# Altium schematic sheet PERIPHERAL.SchDoc
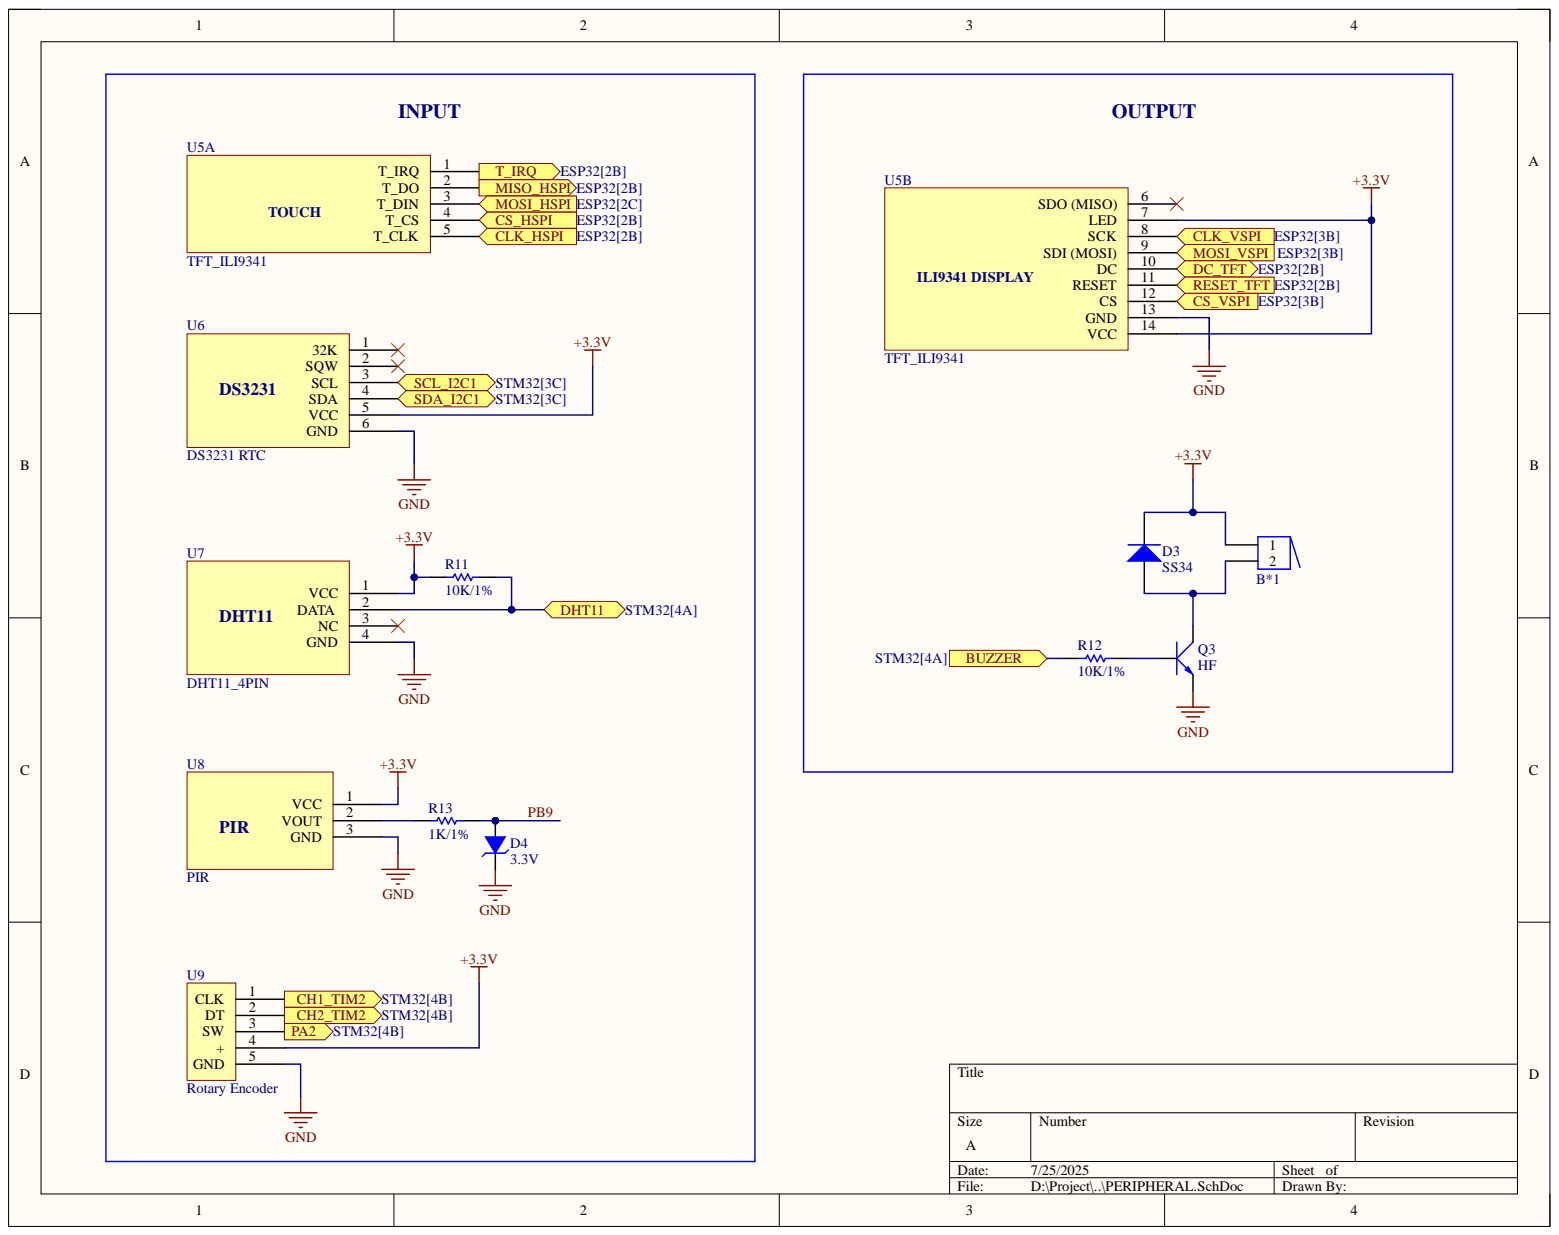
[diagram p0: PERIPHERAL.SchDoc]

## Parts
B*1  Buzzer  [BUZZER]  (value not drawn)
D3  SS34  [DIODE SMA]
D4  3.3V  [DIODE ZENNER SMD SOD80]
Q3  HF  [SOT-23]
R11  value 10K/1%  [RES-SM-0805]  (hidden comment: RESISTOR SMD)
R12  value 10K/1%  [RES-SM-0805]  (hidden comment: RESISTOR SMD)
R13  value 1K/1%  [RES-SM-0805]  (hidden comment: RESISTOR SMD)
U5  TFT_ILI9341  [header 14 pin]  (parts A,B)
U6  DS3231 RTC  [header 6 pin]
U7  DHT11_4PIN  [Header 4 Pin]
U8  PIR  [Header 3 Pin]  (value not drawn)
U9  Rotary Encoder  [Header 5 Pin]

## Ports
BUZZER, CH1_TIM2, CH2_TIM2, CLK_HSPI, CLK_VSPI, CS_HSPI, CS_VSPI, DC_TFT, DHT11, MISO_HSPI, MOSI_HSPI, MOSI_VSPI, PA2, RESET_TFT, SCL_I2C1, SDA_I2C1, T_IRQ

## Nets
+3.3V: B*1.1 D3.2 R11.2 U5.7(LED) U5.14(VCC) U6.5(VCC) U7.1(VCC) U8.1 U9.4(+)
GND: D4.2 Q3.2 U5.13(GND) U6.6(GND) U7.4(GND) U8.3 U9.5(GND)
BUZZER: R12.1 ; port BUZZER
CH1_TIM2: U9.1(CLK) ; port CH1_TIM2
CH2_TIM2: U9.2(DT) ; port CH2_TIM2
CLK_HSPI: U5.5(T_CLK) ; port CLK_HSPI
CLK_VSPI: U5.8(SCK) ; port CLK_VSPI
CS_HSPI: U5.4(T_CS) ; port CS_HSPI
CS_VSPI: U5.12(CS) ; port CS_VSPI
DC_TFT: U5.10(DC) ; port DC_TFT
DHT11: R11.1 U7.2(DATA) ; port DHT11
MISO_HSPI: U5.2(T_DO) ; port MISO_HSPI
MOSI_HSPI: U5.3(T_DIN) ; port MOSI_HSPI
MOSI_VSPI: U5.9(SDI_(MOSI)) ; port MOSI_VSPI
PA2: U9.3(SW) ; port PA2
PB9: D4.1 R13.2
RESET_TFT: U5.11(RESET) ; port RESET_TFT
SCL_I2C1: U6.3(SCL) ; port SCL_I2C1
SDA_I2C1: U6.4(SDA) ; port SDA_I2C1
T_IRQ: U5.1(T_IRQ) ; port T_IRQ
NetB*1_2: B*1.2 D3.1 Q3.3
NetQ3_1: Q3.1 R12.2
NetR13_1: R13.1 U8.2

Not connected: U5 6(SDO_(MISO)); U6 1(32K) 2(SQW); U7 3(NC)

## Library data of the parts
D3 = Diode SS34 ( 1N5822 ): Dán; Don_Vi: Con; Ma_Hang: MH002104; Ten_Linh_Kien: Diode SS34 3A 40V SMA ( 1N5822 SS34A); Chung_Loai: Dán; Component Kind: Standard; Library Name: Altium_Lib_by_KhueNguyenCreator.IntLib
D4 = Diode Zener 3.3V: Dán; Don_Vi: Con; Ma_Hang: MH002880; Ten_Linh_Kien: Diode Zener 1/2W 3.3V SMD1206 ZMM3.3; Chung_Loai: Dán; Component Kind: Standard; Library Name: Altium_Lib_by_KhueNguyenCreator.IntLib
Q3 = Trans C1815 HF SMD: SOT-23; Value: C9014; Component Kind: Standard; Library Name: Altium_Lib_by_KhueNguyenCreator.IntLib
R11-R13 = RESISTOR SMD: Resistor; Component Kind: Standard; Library Name: PIF_Library.IntLib
U6 = DS3231: DS3231 RTC Module; Component Kind: Standard; Library Name: Schematic.SchLib
B*1 = Buzzer: Component Kind: Standard; Library Name: PIF_Library.IntLib
U5 = TFT_ILI9341: Component Kind: Standard; Library Name: Schematic.SchLib
U7 = DHT11_4PIN: Component Kind: Standard; Library Name: Schematic.SchLib
U8 = PIR: Component Kind: Standard; Library Name: Schematic.SchLib
U9 = Rotary Encoder: Component Kind: Standard; Library Name: Libs_NGOCCAM.IntLib
